# Altium schematic sheet door_man.SchDoc
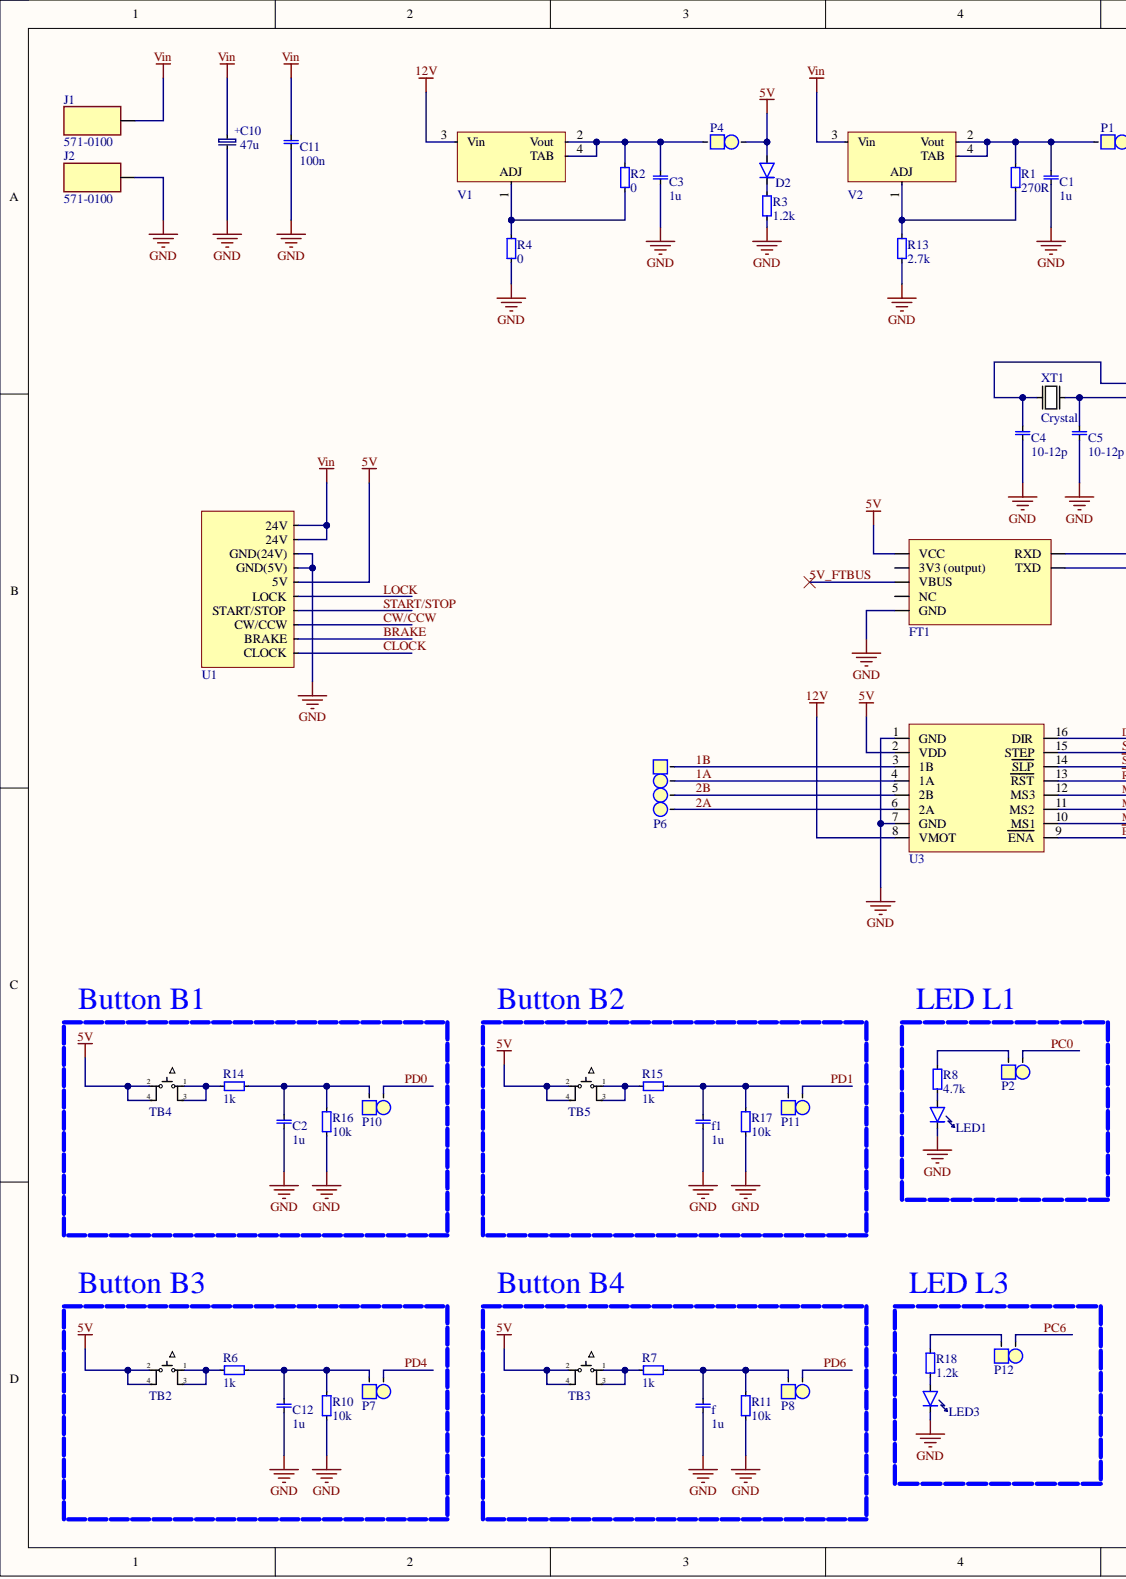
[diagram p0: door_man.SchDoc, left]
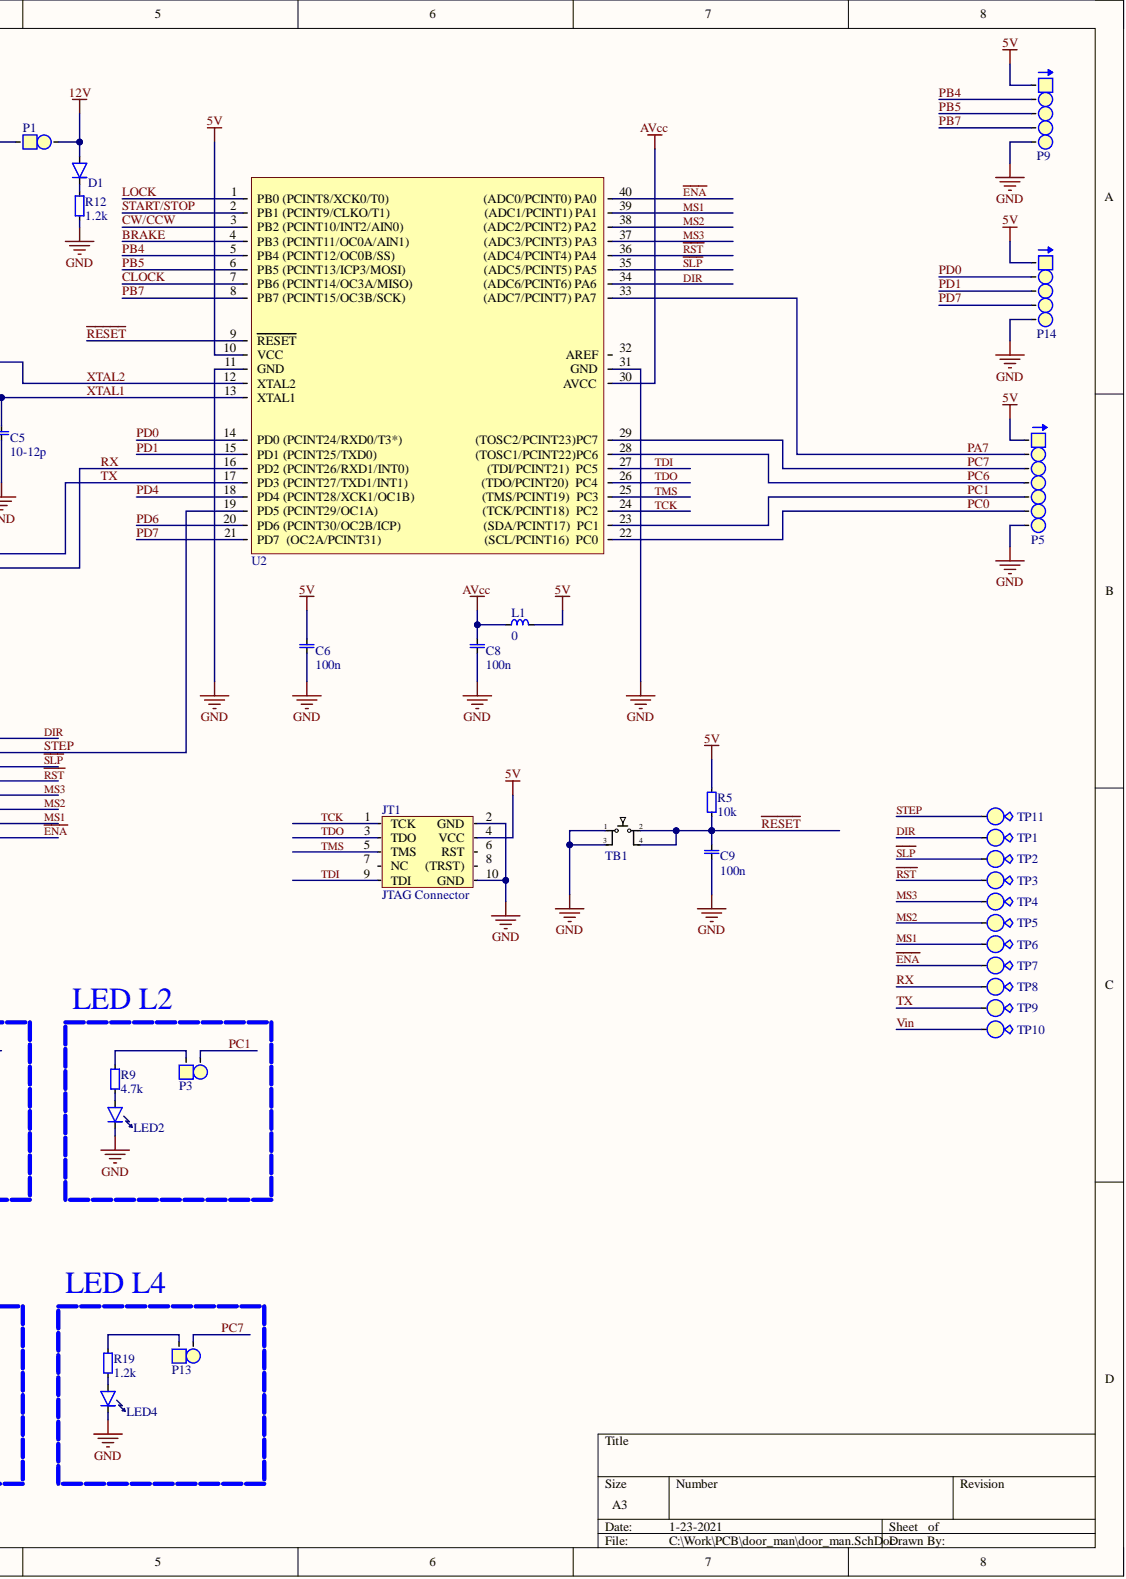
[diagram p1: door_man.SchDoc, right]

## Parts
C1  value 1u  [0603 cap]  (hidden comment: 0603, capacitor)
C2  value 1u  [0603 cap]  (hidden comment: 0603, capacitor)
C3  value 1u  [0603 cap]  (hidden comment: 0603, capacitor)
C4  value 10-12p  [0603 cap]  (hidden comment: 0603, capacitor)
C5  value 10-12p  [0603 cap]  (hidden comment: 0603, capacitor)
C6  value 100n  [0603 cap]  (hidden comment: 0603, capacitor)
C8  value 100n  [0603 cap]  (hidden comment: 0603, capacitor)
C9  value 100n  [0603 cap]  (hidden comment: 0603, capacitor)
C10  value 47u  [CASE_B]  (hidden comment: Cap, case B)
C11  value 100n  [0805, cap]  (hidden comment: 0805, capacitor)
C12  value 1u  [0603 cap]  (hidden comment: 0603, capacitor)
D1  0805, diode  [0805 diode]  (value not drawn)
D2  0805, diode  [0805 diode]  (value not drawn)
f  value 1u  [0603 cap]  (hidden comment: 0603, capacitor)
f1  value 1u  [0603 cap]  (hidden comment: 0603, capacitor)
FT1  FT232_pcb  [ft2323_pcb]  (value not drawn)
J1  571-0100  [Banana Conn VCC]
J2  571-0100  [Banana Conn GND]
JT1  JTAG Connector  [Atmel_JTAG]
L1  value 0  [1812, coil]  (hidden comment: Coil)
LED1  0805, LED  [0805_led]  (value not drawn)
LED2  0805, LED  [0805_led]  (value not drawn)
LED3  0805, LED  [0805_led]  (value not drawn)
LED4  0805, LED  [0805_led]  (value not drawn)
P1  1x2 Pin Header Straight  [padtwo_L]  (value not drawn)
P2  1x2 Pin Header  [1x2HEADER]  (value not drawn)
P3  1x2 Pin Header  [1x2HEADER]  (value not drawn)
P4  1x2 Pin Header Straight  [padtwo_L]  (value not drawn)
P5  1x7 Pin Header  [1x7 Pin Header]  (value not drawn)
P6  4x1 Pin Header  [padFour_L]  (value not drawn)
P7  1x2 Pin Header  [1x2HEADER]  (value not drawn)
P8  1x2 Pin Header  [1x2HEADER]  (value not drawn)
P9  1x5 Pin Header  [padFive_L]  (value not drawn)
P10  1x2 Pin Header  [1x2HEADER]  (value not drawn)
P11  1x2 Pin Header  [1x2HEADER]  (value not drawn)
P12  1x2 Pin Header  [1x2HEADER]  (value not drawn)
P13  1x2 Pin Header  [1x2HEADER]  (value not drawn)
P14  1x5 Pin Header  [padFive_L]  (value not drawn)
R1  value 270R  [0603]  (hidden comment: 0603, resistor)
R2  value 0  [0603]  (hidden comment: 0603, resistor)
R3  value 1.2k  [0603]  (hidden comment: 0603, resistor)
R4  value 0  [0603]  (hidden comment: 0603, resistor)
R5  value 10k  [0603]  (hidden comment: 0603, resistor)
R6  value 1k  [0603]  (hidden comment: 0603, resistor)
R7  value 1k  [0603]  (hidden comment: 0603, resistor)
R8  value 4.7k  [0603]  (hidden comment: 0603, resistor)
R9  value 4.7k  [0603]  (hidden comment: 0603, resistor)
R10  value 10k  [0603]  (hidden comment: 0603, resistor)
R11  value 10k  [0603]  (hidden comment: 0603, resistor)
R12  value 1.2k  [0603]  (hidden comment: 0603, resistor)
R13  value 2.7k  [0603]  (hidden comment: 0603, resistor)
R14  value 1k  [0603]  (hidden comment: 0603, resistor)
R15  value 1k  [0603]  (hidden comment: 0603, resistor)
R16  value 10k  [0603]  (hidden comment: 0603, resistor)
R17  value 10k  [0603]  (hidden comment: 0603, resistor)
R18  value 1.2k  [0603]  (hidden comment: 0603, resistor)
R19  value 1.2k  [0603]  (hidden comment: 0603, resistor)
TB1  Tactile Button  [Button smd]  (value not drawn)
TB2  Tactile Button  [Button smd]  (value not drawn)
TB3  Tactile Button  [Button smd]  (value not drawn)
TB4  Tactile Button  [Button smd]  (value not drawn)
TB5  Tactile Button  [Button smd]  (value not drawn)
TP1  Test Point  [testPoint]  (value not drawn)
TP2  Test Point  [testPoint]  (value not drawn)
TP3  Test Point  [testPoint]  (value not drawn)
TP4  Test Point  [testPoint]  (value not drawn)
TP5  Test Point  [testPoint]  (value not drawn)
TP6  Test Point  [testPoint]  (value not drawn)
TP7  Test Point  [testPoint]  (value not drawn)
TP8  Test Point  [testPoint]  (value not drawn)
TP9  Test Point  [testPoint]  (value not drawn)
TP10  Test Point  [testPoint]  (value not drawn)
TP11  Test Point  [testPoint]  (value not drawn)
U1  BLDC_controller  [1x10 Pin Header H]  (value not drawn)
U2  ATmega1284  [DIP40]  (value not drawn)
U3  A4988_stepstick  [A4988_stepstick]  (value not drawn)
V1  LM317  [SOT223]  (value not drawn)
V2  LM317  [SOT223]  (value not drawn)
XT1  value Crystal  [XTAL_SMD_LP]  (hidden comment: XTAL TXC 7A)

## Nets
5V: C6.1 D2.1 FT1.1(VCC) JT1.4 L1.1 P4.2 P5.1 P9.1 P14.1 R5.0 TB2.2 TB2.4 TB3.2 TB3.4 TB4.2 TB4.4 TB5.2 TB5.4 U1.5(5V) U2.10(VCC) U3.2(VDD)
12V: D1.1 P1.2 U3.8(VMOT) V1.3(Vin)
AVcc: C8.1 L1.2 U2.30(AVCC)
GND: C1.0 C2.0 C3.0 C4.0 C5.0 C6.0 C8.0 C9.0 C10.1 C11.0 C12.0 f.0 f1.0 FT1.7(GND) J2.1 JT1.2 JT1.10 LED1.0 LED2.0 LED3.0 LED4.0 P5.7 P9.5 P14.5 R3.1 R4.1 R10.1 R11.1 R12.1 R13.1 R16.1 R17.1 TB1.1 TB1.3 U1.3(GND(24V)) U1.4(GND(5V)) U2.11(GND) U2.31(GND) U3.1(GND) U3.7(GND)
1A: P6.2 U3.4(1A)
1B: P6.1 U3.3(1B)
2A: P6.4 U3.6(2A)
2B: P6.3 U3.5(2B)
5V_FTBUS: FT1.3(VBUS)
BRAKE: U1.9(BRAKE) U2.4(PB3_(PCINT11/OC0A/AIN1))
CLOCK: U1.10(CLOCK) U2.7(PB6_(PCINT14/OC3A/MISO))
CW/CCW: U1.8(CW/CCW) U2.3(PB2_(PCINT10/INT2/AIN0))
DIR: TP1.0 U2.34((ADC6/PCINT6)_PA6) U3.16(DIR)
~{ENA}: TP7.0 U2.40((ADC0/PCINT0)_PA0) U3.9(ENA)
LOCK: U1.6(LOCK) U2.1(PB0_(PCINT8/XCK0/T0))
MS1: TP6.0 U2.39((ADC1/PCINT1)_PA1) U3.10(MS1)
MS2: TP5.0 U2.38((ADC2/PCINT2)_PA2) U3.11(MS2)
MS3: TP4.0 U2.37((ADC3/PCINT3)_PA3) U3.12(MS3)
PA7: P5.2 U2.33((ADC7/PCINT7)_PA7)
PB4: P9.2 U2.5(PB4_(PCINT12/OC0B/SS))
PB5: P9.3 U2.6(PB5_(PCINT13/ICP3/MOSI))
PB7: P9.4 U2.8(PB7_(PCINT15/OC3B/SCK))
PC0: P2.2 P5.6 U2.22(PC0)
PC1: P3.2 P5.5 U2.23(PC1)
PC6: P5.4 P12.2 U2.28((TOSC1/PCINT22)PC6)
PC7: P5.3 P13.2 U2.29((TOSC2/PCINT23)PC7)
PD0: P10.2 P14.2 U2.14(PD0_(PCINT24/RXD0/T3*))
PD1: P11.2 P14.3 U2.15(PD1_(PCINT25/TXD0))
PD4: P7.2 U2.18(PD4_(PCINT28/XCK1/OC1B))
PD6: P8.2 U2.20(PD6_(PCINT30/OC2B/ICP))
PD7: P14.4 U2.21(PD7)
~{RESET}: C9.1 R5.1 TB1.2 TB1.4 U2.9(RESET)
~{RST}: TP3.0 U2.36((ADC4/PCINT4)_PA4) U3.13(RST)
RX: FT1.4(TXD) TP8.0 U2.16(PD2_(PCINT26/RXD1/INT0))
~{SLP}: TP2.0 U2.35((ADC5/PCINT5)_PA5) U3.14(SLP)
START/STOP: U1.7(START/STOP) U2.2(PB1_(PCINT9/CLKO/T1))
STEP: TP11.0 U2.19(PD5_(PCINT29/OC1A)) U3.15(STEP)
TCK: JT1.1 U2.24(PC2)
TDI: JT1.9 U2.27(PC5)
TDO: JT1.3 U2.26(PC4)
TMS: JT1.5 U2.25(PC3)
TX: FT1.5(RXD) TP9.0 U2.17(PD3_(PCINT27/TXD1/INT1))
Vin: C10.2 C11.1 J1.1 TP10.0 U1.1(24V) U1.2(24V) V2.3(Vin)
XTAL1: C5.1 U2.13(XTAL1) XT1.1
XTAL2: C4.1 U2.12(XTAL2) XT1.2
NetC1_1: C1.1 P1.1 R1.0 V2.2(Vout) V2.4(TAB)
NetC2_1: C2.1 P10.1 R14.0 R16.0
NetC3_1: C3.1 P4.1 R2.0 V1.2(Vout) V1.4(TAB)
NetC12_1: C12.1 P7.1 R6.0 R10.0
NetD1_0: D1.0 R12.0
NetD2_0: D2.0 R3.0
Netf1_1: f1.1 P11.1 R15.0 R17.0
Netf_1: f.1 P8.1 R7.0 R11.0
NetLED1_1: LED1.1 R8.1
NetLED2_1: LED2.1 R9.1
NetLED3_1: LED3.1 R18.1
NetLED4_1: LED4.1 R19.1
NetP2_1: P2.1 R8.0
NetP3_1: P3.1 R9.0
NetP12_1: P12.1 R18.0
NetP13_1: P13.1 R19.0
NetR1_1: R1.1 R13.0 V2.1
NetR2_1: R2.1 R4.0 V1.1
NetR6_1: R6.1 TB2.1 TB2.3
NetR7_1: R7.1 TB3.1 TB3.3
NetR14_1: R14.1 TB4.1 TB4.3
NetR15_1: R15.1 TB5.1 TB5.3

Not connected: FT1 2(3V3_(output)) 6(NC); JT1 6 7 8; U2 32(AREF)

## Library data of the parts
J1 J2 = Banana conn: Banana Connector 571-0100; Manufacturer_Name: Deltron; Component Kind: Standard; Datasheet Link: https://www.dem-uk.com/deltron-components/Data/Product_Downloads/deltron-571-0100-4mm-90deg-single-socket-data.pdf; Height: 12.4mm; Manufacturer_Part_Number: 571-0100; Mouser Part Number: 164-6218; Mouser Price/Stock: https://www.mouser.com/Search/Refine.aspx?Keyword=164-6218; Library Name: jclib.IntLib
JT1 = Atmel JTAG: Atmel JTAG Connector; Component Kind: Standard; Library Name: jclib.IntLib
LED1-LED4 = 0805, LED: Value: 0805 LED; Component Kind: Standard; Farnell: 8529930; Library Name: eitp15_lab1_lib.IntLib
P1 P4 = 1x2 Pin Header Straight: 2.54 mm, TH; Component Kind: Standard; Value: 1x2 Pin Header; Library Name: jclib.IntLib
P2 P3 P7 P8 P10-P13 = 1x2 Pin Header: 2.54 mm, TH; Component Kind: Standard; Value: 1x2 Pin Header; Library Name: eitp15_lab1_lib.IntLib
P5 = 1x7 Pin Header: 2.54 mm; Value: 1x8 Pin Header; Component Kind: Standard; Library Name: jclib.IntLib
P6 = 1x4 Pin Header: 2.54 mm; Component Kind: Standard; Value: 4x1 Pin Header; Library Name: jclib.IntLib
P9 P14 = 1x5 Pin Header: 2.54 mm; Component Kind: Standard; Value: 1x5 Pin Header; Library Name: jclib.IntLib
TB1-TB5 = Button SMD: Tactile Switch Small Low; Component Kind: Standard; ELFA: 110-87-406; Farnell: 2320087; Value: Button SMD; Library Name: eitp15_lab1_lib.IntLib
U1 = BLDC_controller: BLDC with controller. Pitch: 2.54 mm; Value: 1x8 Pin Header; Component Kind: Standard; Library Name: jclib.IntLib
U2 = ATmega1284: 8-bit Atmel Microcontroller with 128K Bytes  In-System Programmable Flash; Component Kind: Standard; Value: ATmega1284; Library Name: jclib.IntLib
V1 V2 = LM317 SOT223: Adjustable Voltage Regulator; Component Kind: Standard; Farnell: 1469023; Value: LM317; Library Name: jclib.IntLib
XT1 = XTAL TXC 7A: 16 MHz, SMD, 5mm x 3,2mm, 30 ppm; Component Kind: Standard; Farnell: 1841946; Library Name: eitp15_lab1_lib.IntLib
C1-C6 C8 C9 C12 f f1 = 0603, capacitor: Component Kind: Standard; Library Name: jclib.IntLib
C10 = Cap, case B: Component Kind: Standard; Library Name: jclib.IntLib
C11 = 0805, capacitor: Component Kind: Standard; Library Name: jclib.IntLib
D1 D2 = 0805, diode: Component Kind: Standard; Library Name: jclib.IntLib
FT1 = FT232_pcb: Component Kind: Standard; Library Name: jclib.IntLib
L1 = Coil: Component Kind: Standard; Library Name: jclib.IntLib
R1-R19 = 0603, resistor: Component Kind: Standard; Library Name: jclib.IntLib
TP1-TP11 = Test Point: Component Kind: Standard; Farnell: 1702006; Value: Test point; Library Name: jclib.IntLib
U3 = A4988_stepstick: Component Kind: Standard; Library Name: jclib.IntLib
